# Altium schematic sheet 7SegMulti.SchDoc(7SegMulti)
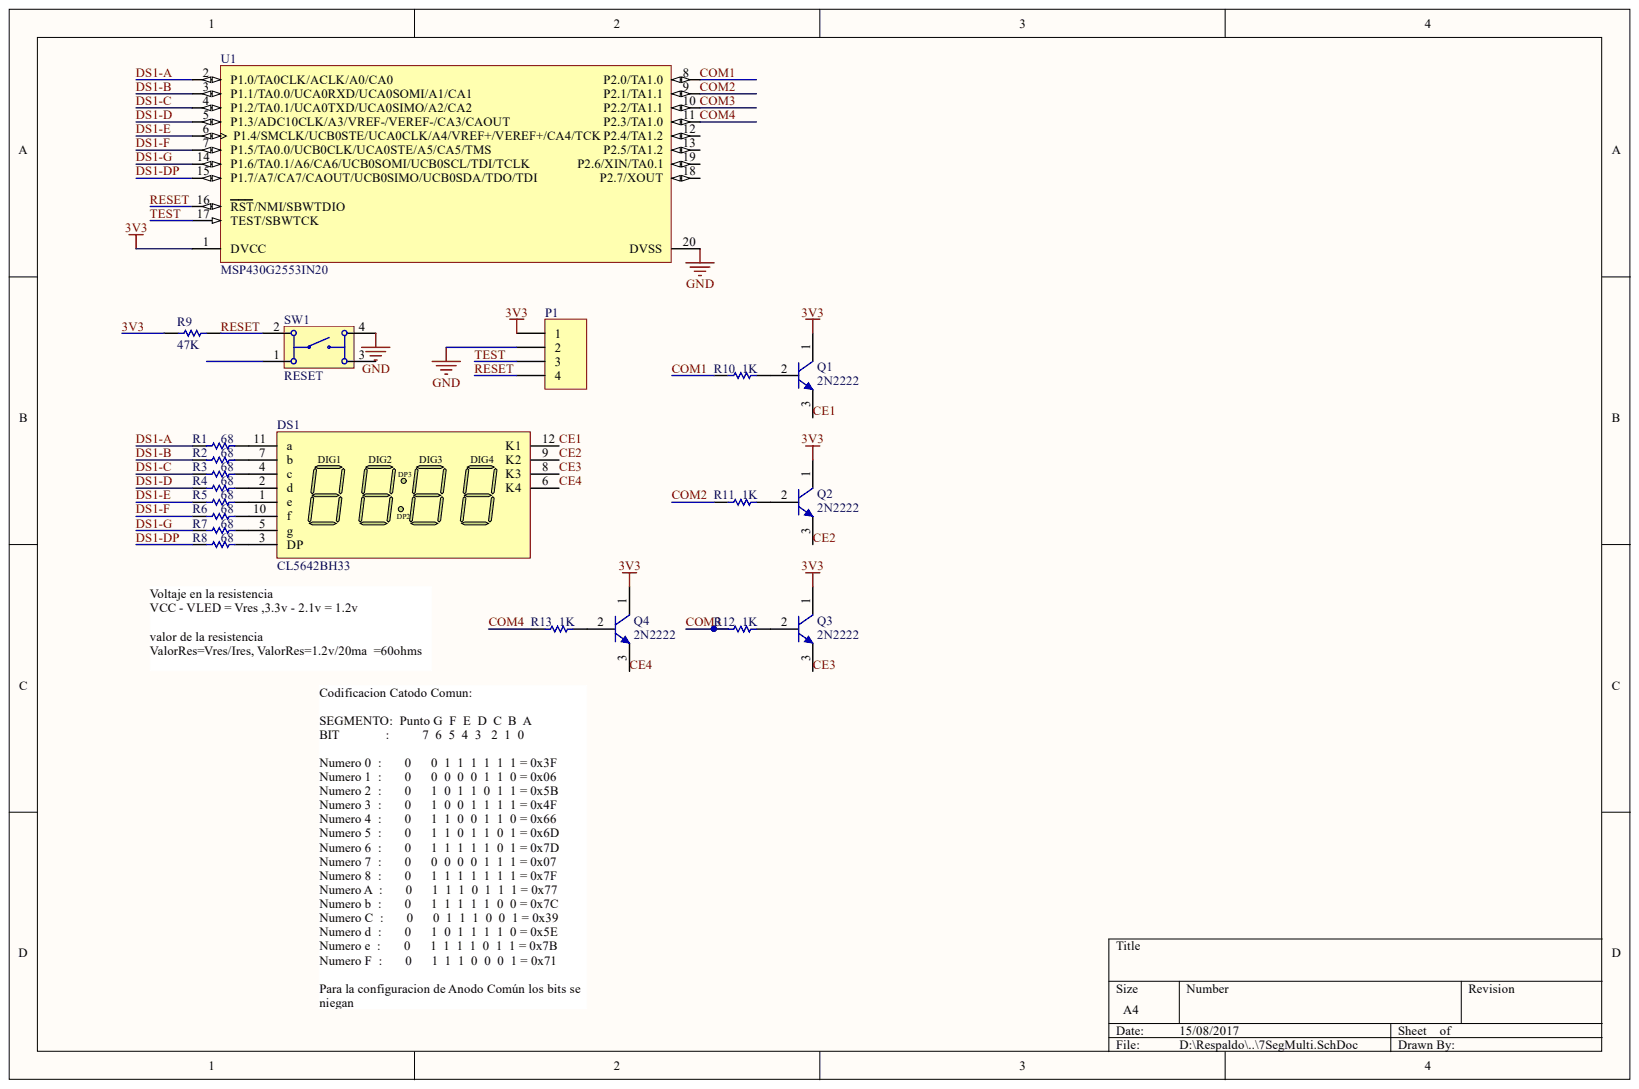
[diagram p0: 7SegMulti.SchDoc]

## Parts
DS1  CL5642BH33  [B-88]
P1  (no value)  [HDR1X4]
Q1  2N2222  [ONSC-TO-92-3-29-11_AM_BL]
Q2  2N2222  [ONSC-TO-92-3-29-11_AM_BL]
Q3  2N2222  [ONSC-TO-92-3-29-11_AM_BL]
Q4  2N2222  [ONSC-TO-92-3-29-11_AM_BL]
R1  value 68  [AXIAL-0.3]  (hidden comment: Res1)
R2  value 68  [AXIAL-0.3]  (hidden comment: Res1)
R3  value 68  [AXIAL-0.3]  (hidden comment: Res1)
R4  value 68  [AXIAL-0.3]  (hidden comment: Res1)
R5  value 68  [AXIAL-0.3]  (hidden comment: Res1)
R6  value 68  [AXIAL-0.3]  (hidden comment: Res1)
R7  value 68  [AXIAL-0.3]  (hidden comment: Res1)
R8  value 68  [AXIAL-0.3]  (hidden comment: Res1)
R9  value 47K  [AXIAL-0.3]  (hidden comment: Res1)
R10  value 1K  [AXIAL-0.3]  (hidden comment: Res1)
R11  value 1K  [AXIAL-0.3]  (hidden comment: Res1)
R12  value 1K  [AXIAL-0.3]  (hidden comment: Res1)
R13  value 1K  [AXIAL-0.3]  (hidden comment: Res1)
SW1  RESET  [430476085716]
U1  MSP430G2553IN20  [TI-N20]

## Nets
GND: P1.2 SW1.4 U1.20(DVSS)
3V3: P1.1 Q1.1 Q2.1 Q3.1 Q4.1 R9.1 U1.1(DVCC)
CE1: DS1.12(K1) Q1.3
CE2: DS1.9(K2) Q2.3
CE3: DS1.8(K3) Q3.3
CE4: DS1.6(K4) Q4.3
COM1: R10.1 U1.8(P2.0/TA1.0)
COM2: R11.1 U1.9(P2.1/TA1.1)
COM3: R12.1 U1.10(P2.2/TA1.1)
COM4: R13.1 U1.11(P2.3/TA1.0)
DS1-A: R1.1 U1.2(P1.0/TA0CLK/ACLK/A0/CA0)
DS1-B: R2.1 U1.3(P1.1/TA0.0/UCA0RXD/UCA0SOMI/A1/CA1)
DS1-C: R3.1 U1.4(P1.2/TA0.1/UCA0TXD/UCA0SIMO/A2/CA2)
DS1-D: R4.1 U1.5(P1.3/ADC10CLK/A3/VREF-/VEREF-/CA3/CAOUT)
DS1-DP: R8.1 U1.15(P1.7/A7/CA7/CAOUT/UCB0SIMO/UCB0SDA/TDO/TDI)
DS1-E: R5.1 U1.6(P1.4/SMCLK/UCB0STE/UCA0CLK/A4/VREF+/VEREF+/CA4/TCK)
DS1-F: R6.1 U1.7(P1.5/TA0.0/UCB0CLK/UCA0STE/A5/CA5/TMS)
DS1-G: R7.1 U1.14(P1.6/TA0.1/A6/CA6/UCB0SOMI/UCB0SCL/TDI/TCLK)
RESET: P1.4 R9.2 SW1.2 U1.16(RST/NMI/SBWTDIO)
TEST: P1.3 U1.17(TEST/SBWTCK)
NetDS1_1: DS1.1(e) R5.2
NetDS1_2: DS1.2(d) R4.2
NetDS1_3: DS1.3(DP) R8.2
NetDS1_4: DS1.4(c) R3.2
NetDS1_5: DS1.5(g) R7.2
NetDS1_7: DS1.7(b) R2.2
NetDS1_10: DS1.10(f) R6.2
NetDS1_11: DS1.11(a) R1.2
NetQ1_2: Q1.2 R10.2
NetQ2_2: Q2.2 R11.2
NetQ3_2: Q3.2 R12.2
NetQ4_2: Q4.2 R13.2

Not connected: SW1 1 3; U1 12(P2.4/TA1.2) 13(P2.5/TA1.2) 18(P2.7/XOUT) 19(P2.6/XIN/TA0.1)

## Library data of the parts
DS1 = HDSP-B03G: 14.22 mm Tinted Green, 88:88 4-Digit 7-Segment Display: CC, Gray Surface; LuminousIntensity: 2000 ucd (Minimum).; Component Kind: Standard; ComponentLink1Description: Manufacturer Link; ComponentLink1URL: http://www.agilent.com/; ComponentLink2Description: Datasheet; ComponentLink2URL: http://cp.literature.agilent.com/litweb/pdf/5988-7859EN.pdf; DatasheetVersion: 23-Sep-2002; LatestRevisionDate: 11-Oct-2004; LatestRevisionNote: Display outline superimposed on PCB Footprint.; LED_Colour: Green; Library Name: Agilent LED Display 7-Segment, 4-Digit.IntLib; PackageDescription: LED, 7-Segment Display, 14.22 mm, Four Digit, 88:88; 12 Leads; Row Space 15.24 mm; Pitch 2.54 mm; PackageReference: B-88; PackageVersion: 21-Aug-2001; Published: 26-May-2004; Simulation: HDSP_B03G; Publisher: Altium Limited
P1 = Header 4: Header, 4-Pin; Component Kind: Standard; LatestRevisionDate: 17-Jul-2002; LatestRevisionNote: Re-released for DXP Platform.; Library Name: Miscellaneous Connectors.IntLib; Signal Integrity: Connector; Publisher: Altium Limited
Q1-Q4 = P2N2222ARL1G: Amplifier Transistor, NPN Silicon, 3-Pin TO-92, Pb-Free, Tape and Reel; Manufacturer: On Semiconductor; fT Min (MHz): 300; IC Continuous (A): 0.6; Component Kind: Standard; ComponentLink1Description: Manufacturer URL; ComponentLink1URL: http://www.onsemi.com/; ComponentLink2Description: Datasheet; ComponentLink2URL: http://www.onsemi.com/pub_link/Collateral/P2N2222A-D.PDF; ComponentLink3Description: Package Specification; ComponentLink3URL: http://www.onsemi.com/pub_link/Collateral/MAC97-D.PDF; DatasheetVersion: Rev. 7; Library Name: ON Semi General Purpose Transistors.IntLib; Mounting Technology: Through Hole; PackageDescription: 3-Pin Transistor Outline, Body 4.825 x 3.685 mm, Pitch 1.27 mm; PackageReference: TO-92-3-29-11_AM_BL; PackageVersion: Rev. AM; Packing: Tape and Reel; PartNumber: P2N2222ARL1G; Polarity: NPN; RoHS: TRUE; Supplier 1: Digi-Key; Supplier 2: Mouser; Supplier Part Number 1: P2N2222ARL1G-ND; Supplier Part Number 2: 863-P2N2222ARL1G
R1-R13 = Res1: Resistor; Component Kind: Standard; LatestRevisionDate: 17-Jul-2002; LatestRevisionNote: Re-released for DXP Platform.; Library Name: Miscellaneous Devices.IntLib; PackageDescription: Axial Device, Thru-Hole; 2 Leads; 0.3 in Pin Spacing; PackageReference: AXIAL-0.3; Published: 8-Jun-2000; Signal Integrity: Res; Simulation: RESISTOR; Publisher: Altium Limited
SW1 = WE-Tact Switches WS-TATV 430476085716: WS-TATV THT Tact Switch 12X12 mm; Manufacturer: W¨¹rth Elektronik eiSos GmbH & Co.KG; ComponentLink1 Description: Manufacturer; ComponentLink2 Description: Datasheet; Creat by: Karrer Zheng; NoPins: 04; Component Kind: Standard; ComponentLink1URL: http://www.we-online.com; ComponentLink2URL: http://katalog.we-online.de/em/datasheet/430476085716.pdf; Creat Date: 28 February 2014; Library Name: Tact_Switches.intlib; partNo: 430476085716
U1 = MSP430G2553IN20: 16 MHz Mixed Signal Microcontroller with 16 KB Flash, 512 B SRAM and 24 GPIOs, -40 to 85 degC, 20-pin DIP (N), Pb-Free (RoHS); Manufacturer: Texas Instruments; ADC: 10-bit SAR; ADC Channels: 8; Brown Out Reset: Yes; Flash(KB): 16; GPIO: 24; SRAM(B): 512; SubFamily: Value Line; Timers - 16-bit: 2; USCI_A (UART/LIN/IrDA/SPI): 1; Cap touch I/O: Yes; Code_JEDEC: MS-001; Comparators: Yes; Component Kind: Standard; ComponentLink1Description: Manufacturer URL; ComponentLink1URL: http://www.ti.com/; ComponentLink2Description: Datasheet; ComponentLink2URL: http://www.ti.com/general/docs/lit/getliterature.tsp?genericPartNumber=MSP430G2553&fileType=pdf; ComponentLink3Description: Package Specification; ComponentLink3URL: http://www.ti.com/litv/pdf/mpds177g; DatasheetVersion: SLAS735G; Eco-Plan: Pb-Free (RoHS); Frequency(MHz): 16; Library Name: TI Microcontroller MSP430 16-bit Ultra-Low Power.IntLib; Mounting Technology: Through Hole; PackageDescription: 20-Pin Plastic In-Line, Body 25.40 x 6.35 mm, Pitch 2.54 mm; PackageReference: N20; PackageVersion: 4040049/E; PartNumber: MSP430G2553IN20; Supplier 1: Digi-Key; Supplier 2: Mouser; Supplier Part Number 1: MSP430G2533IRHB32T-ND; Supplier Part Number 2: 595-P430G2533IRHB32T; Temp Sensor: Yes; USCI_B (I2C & SPI): 1; Watchdog: Yes
